# Altium schematic sheet ГРЛМ.465333.005 Э3.SchDoc
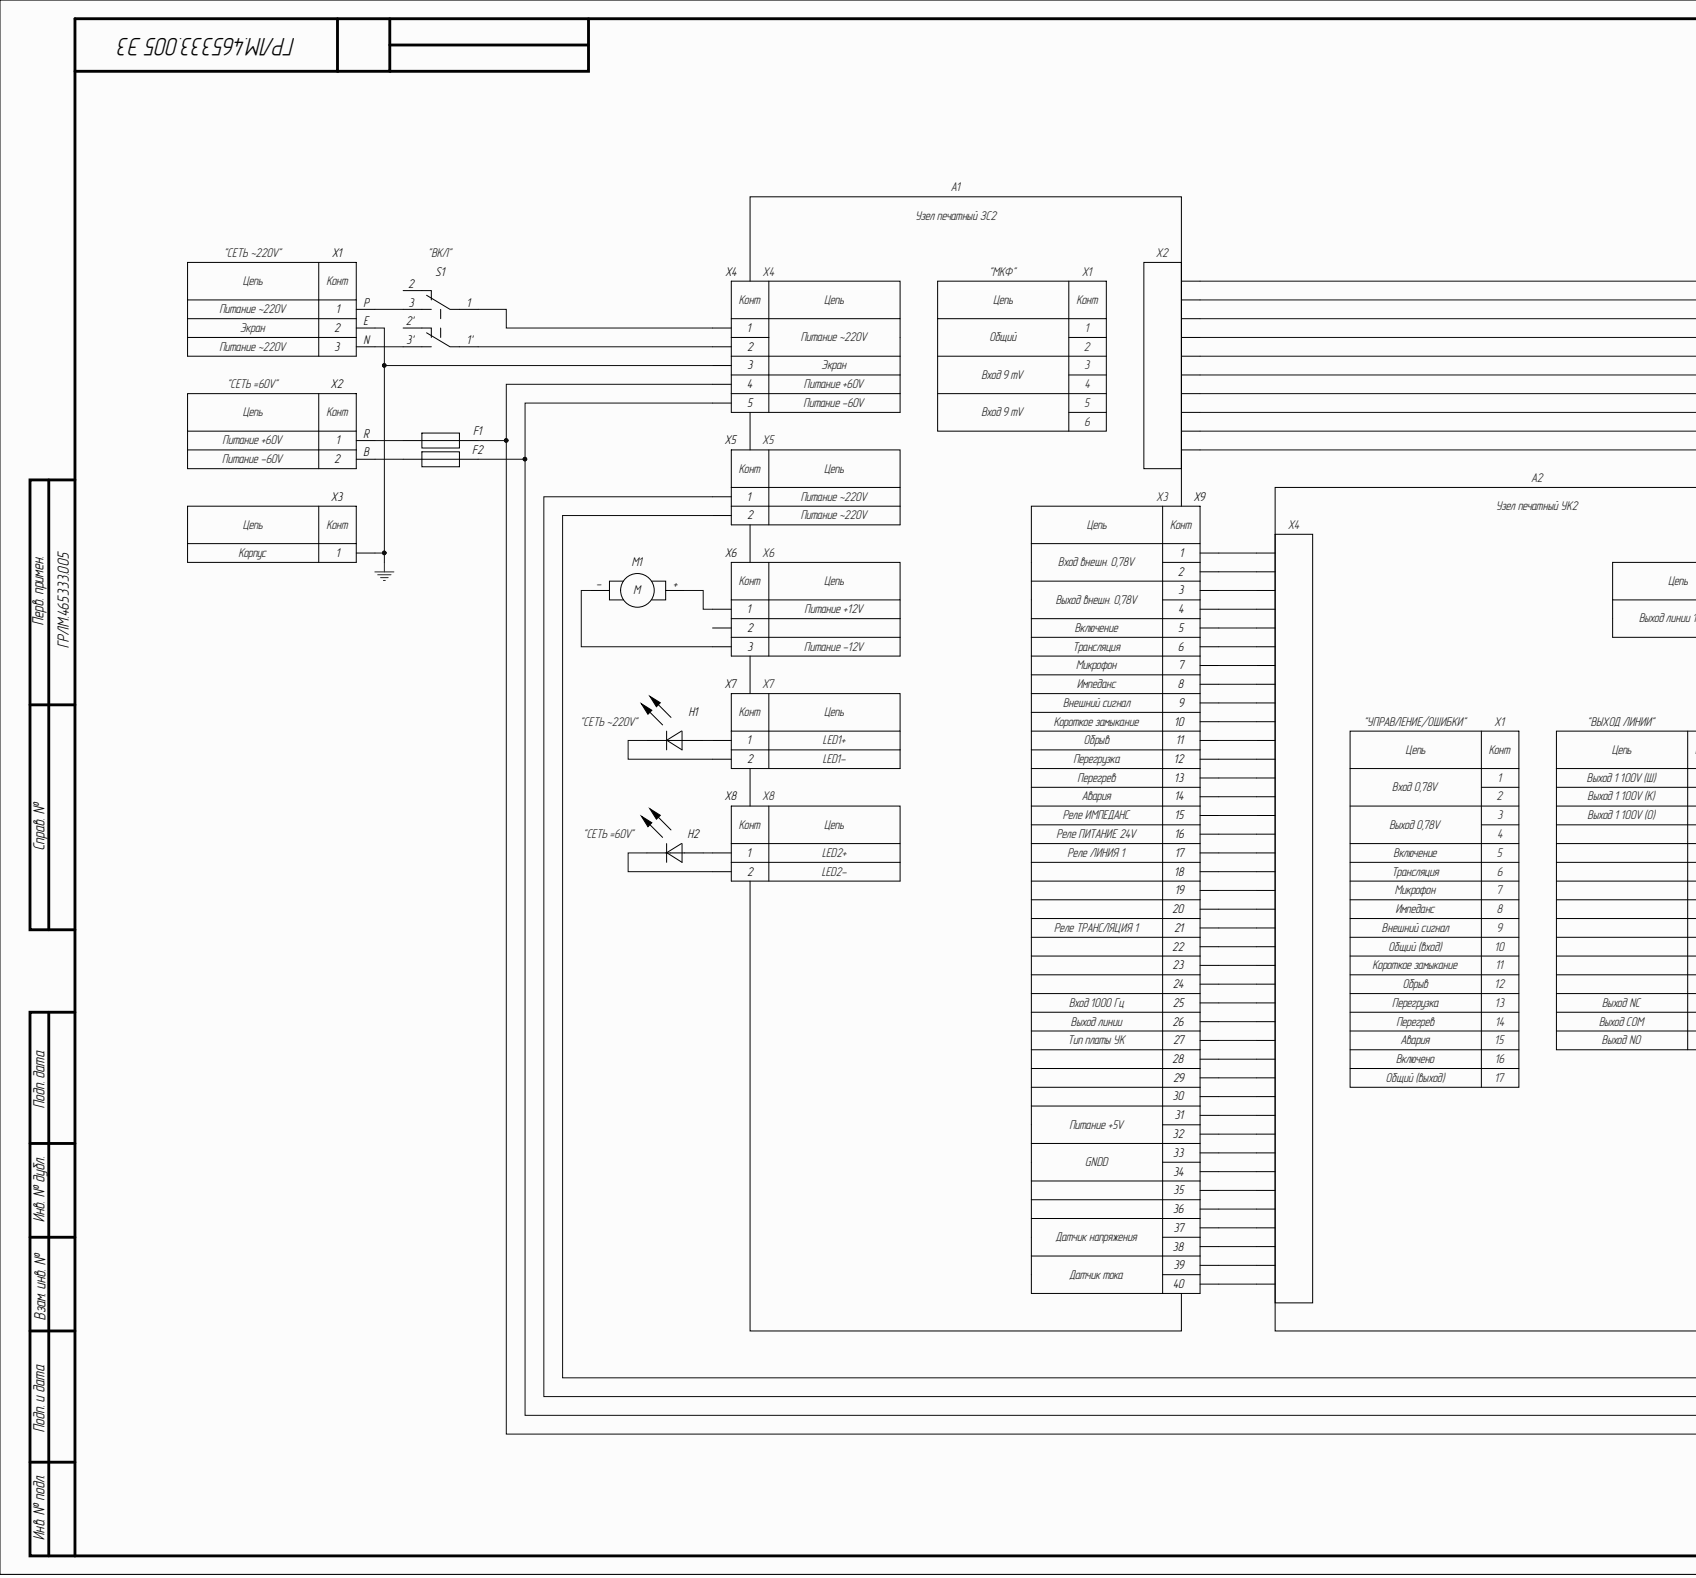
[diagram p0: ГРЛМ.465333.005 Э3.SchDoc, left]
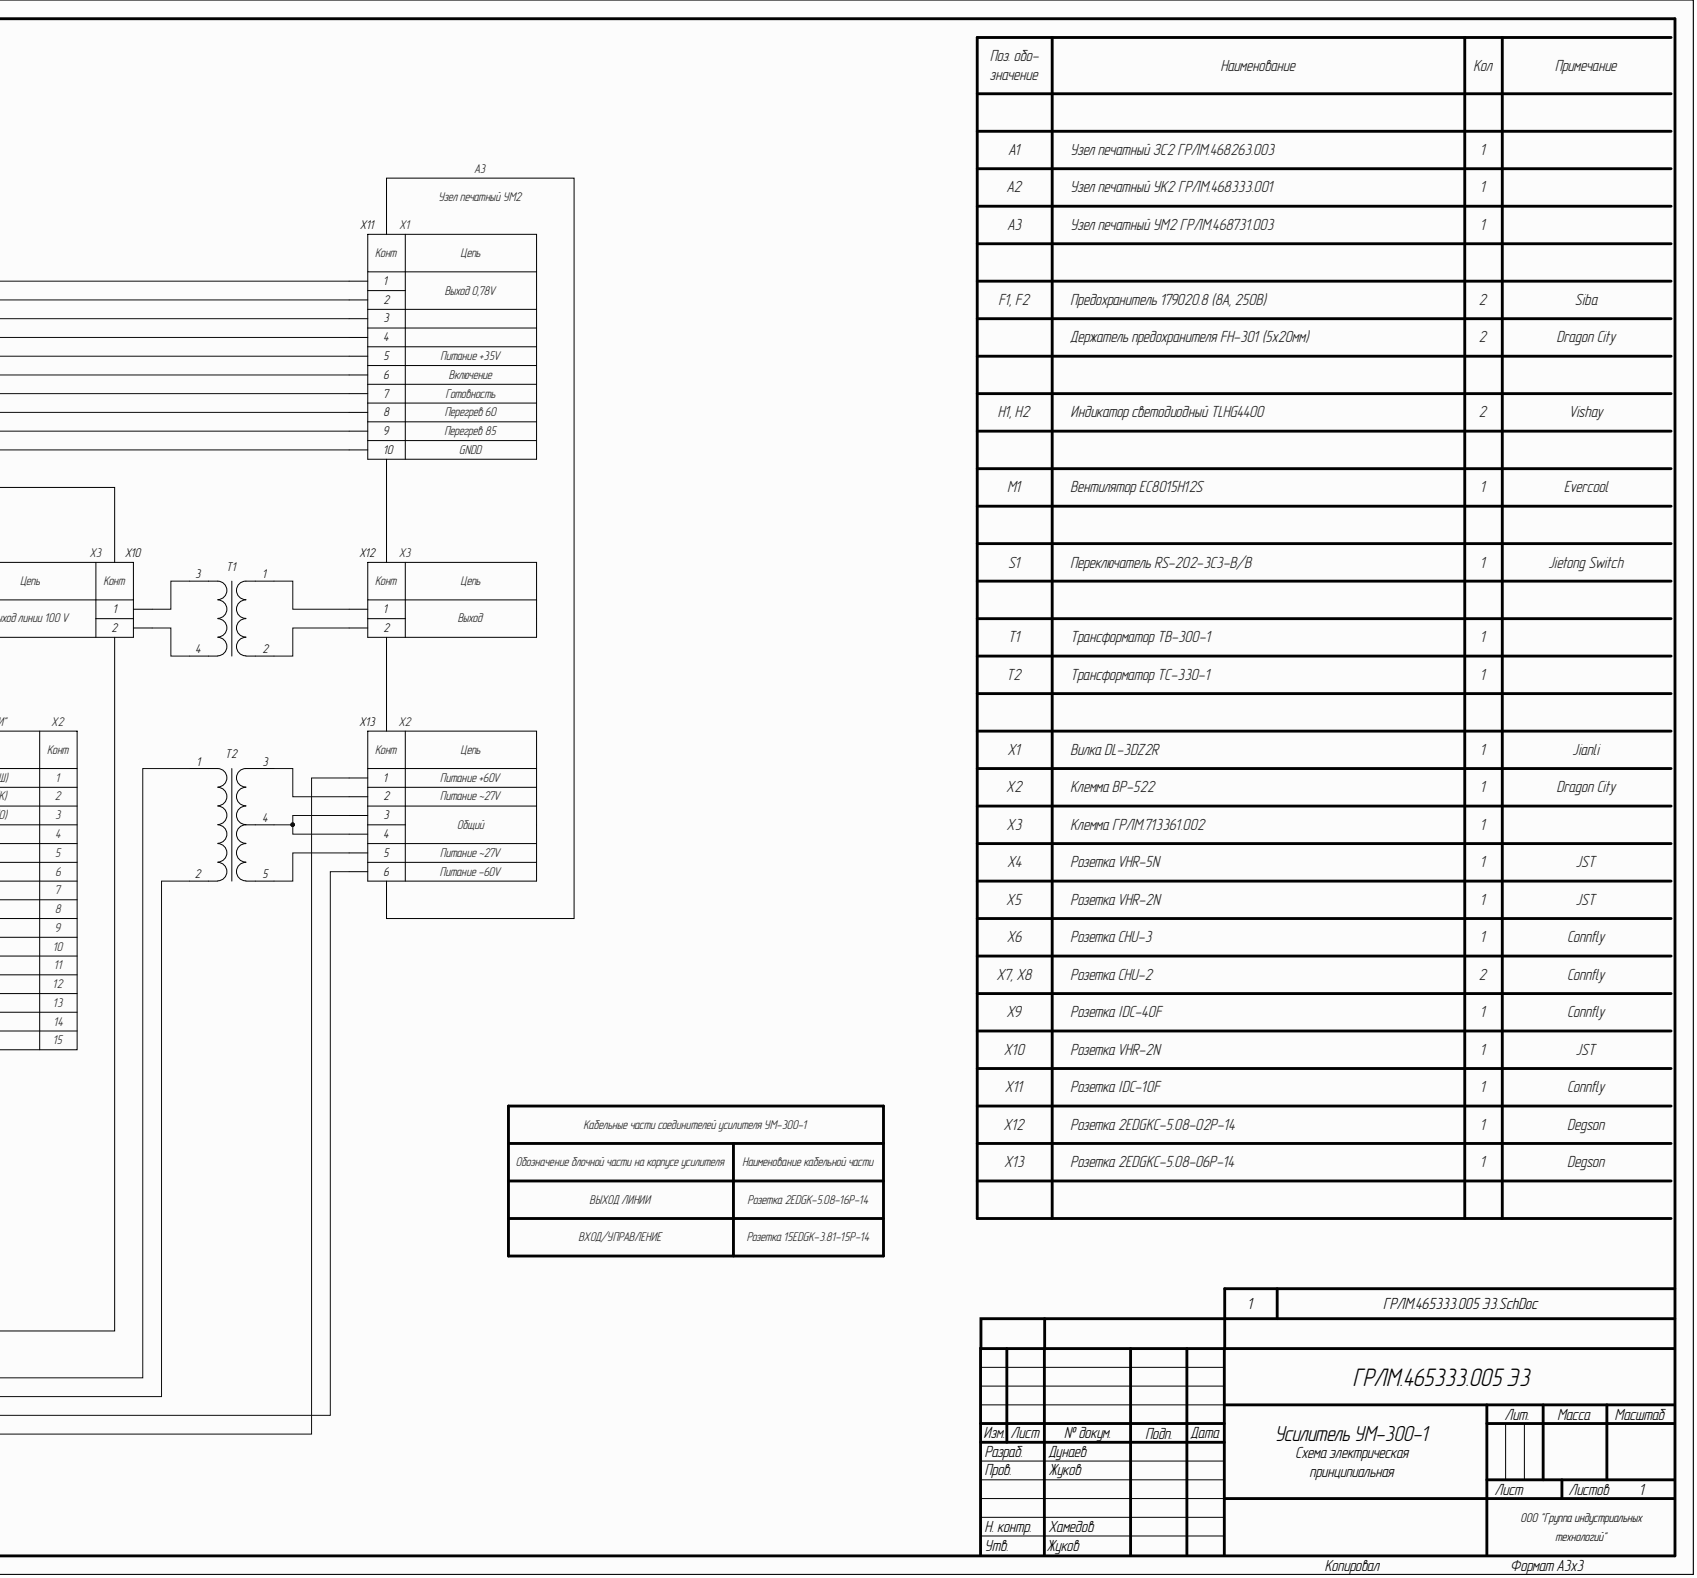
[diagram p1: ГРЛМ.465333.005 Э3.SchDoc, right]

## Parts
F1  Fuse_5x20  (value not drawn)
F2  Fuse_5x20  (value not drawn)
H1  TLHG4400
H2  TLHG4400
M1  DA04020B12L  (value not drawn)
S1  RS-202A-3C3-B/B  (value not drawn)
T1  ТЗ-30/15,5/100  (value not drawn)
T2  ТТП-400  (value not drawn)
X1  DL-3DZ2R  (value not drawn)
X2  BP-522  (value not drawn)
X3  Zazemlenie  (value not drawn)
X4  VHR-5N  (value not drawn)
X5  VHR-2N  (value not drawn)
X6  CHU-3  (value not drawn)
X7  CHU-2  (value not drawn)
X8  CHU-2  (value not drawn)
X9  IDC-40F  (value not drawn)
X10  VHR-2N  (value not drawn)
X11  IDC-10F  (value not drawn)
X12  2EDGKC-5.08-02P-14
X13  2EDGKC-5.08-06P-14

## Nets
SHIELD: X1.E X3.1 X4.3
NetF1_1: F1.1 X2.R
NetF1_2: F1.2 X4.4 X13.1
NetF2_1: F2.1 X2.B
NetF2_2: F2.2 X4.5 X13.6
NetH1_1: H1.1 X7.2
NetH1_2: H1.2 X7.1
NetH2_1: H2.1 X8.2
NetH2_2: H2.2 X8.1
NetM1_+: M1.+ X6.1
NetM1_-: M1.- X6.3
NetS1_1: S1.1 X4.1
NetS1_1': S1.1' X4.2
NetS1_3: S1.3 X1.P
NetS1_3': S1.3' X1.N
NetT1_1: T1.1 X12.1
NetT1_2: T1.2 X12.2
NetT1_3: T1.3 X10.1
NetT1_4: T1.4 X10.2
NetT2_1: T2.1 X5.2
NetT2_2: T2.2 X5.1
NetT2_3: T2.3 X13.2
NetT2_4: T2.4 X13.3 X13.4
NetT2_5: T2.5 X13.5

Not connected: S1 2 2'; X6 2; X9 1 2 3 4 5 6 7 8 9 10 11 12 13 14 15 16 17 18 19 20 21 22 23 24 25 26 27 28 29 30 31 32 33 34 35 36 37 38 39 40; X11 1 2 3 4 5 6 7 8 9 10

## Library data of the parts
F1 F2 = Fuse_5x20: Вставка плавкая 5x20; Component Kind: Standard; Library Name: Прочее.SchLib
H1 H2 = TLHG4400: Светодиод выв. зел. 3мм; Component Kind: Standard; Library Name: Устройства индикации.SchLib
M1 = DA04020B12L: Вентилятор 12V; Component Kind: Standard; Library Name: Прочее.SchLib
S1 = RS-202A-3C3-B/B: Переключатель 2-пол.; Component Kind: Standard; Library Name: Коммутационные изделия.SchLib
T1 = ТЗ-30/15,5/100: Трансформатор согласующий; ТУ: ТУ3468‐005‐53494025‐10; Component Kind: Standard; Library Name: Индуктивные элементы.SchLib
T2 = ТТП-400: Трансформатор сетевой; Type: ТТП-400 (2х25В, 7.5А) Торэл; ТУ: ТУ3468‐005‐53494025‐10; Component Kind: Standard; Library Name: Индуктивные элементы.SchLib
X1 = DL-3DZ2R: Вилка сетевая с предохранителем и фильтром; Component Kind: Standard; Library Name: Соединители.SchLib
X2 = BP-522: Клеммник черно-красный; Component Kind: Standard; Library Name: Соединители.SchLib
X3 = Zazemlenie: Клемма заземления; Component Kind: Standard; Library Name: Прочее.SchLib
X4 = VHR-5N: Розетка; Component Kind: Standard; Library Name: Соединители.SchLib
X5 X10 = VHR-2N: Розетка; Component Kind: Standard; Library Name: Соединители.SchLib
X6 = CHU-3: Розетка; Component Kind: Standard; Library Name: Соединители.SchLib
X7 X8 = CHU-2: Розетка; Component Kind: Standard; Library Name: Соединители.SchLib
X9 = IDC-40F: Розетка; Component Kind: Standard; Library Name: Соединители.SchLib
X11 = IDC-10F: Вилка; Component Kind: Standard; Library Name: Соединители.SchLib
X12 = 2EDGKC-5.08-02P-14: Розетка; Component Kind: Standard; Library Name: Соединители.SchLib
X13 = 2EDGKC-5.08-06P-14: Розетка; Component Kind: Standard; Library Name: Соединители.SchLib
